# Altium schematic sheet sheet02.SchDoc
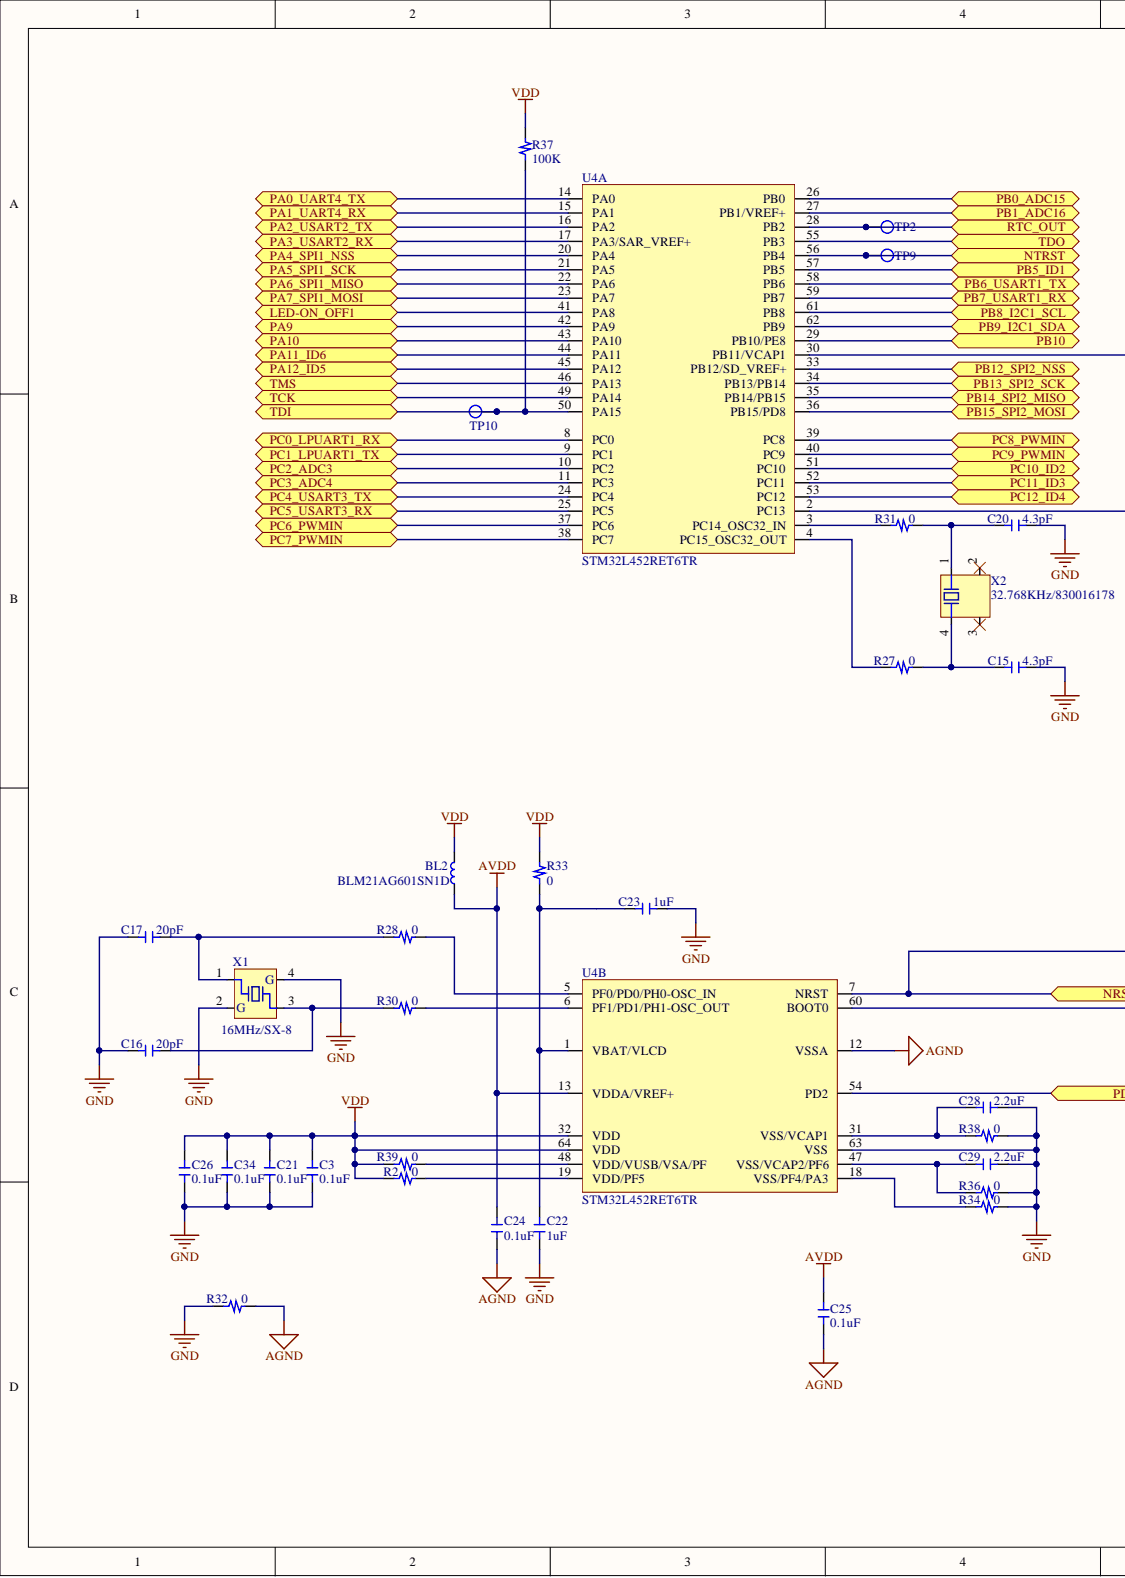
[diagram p0: sheet02.SchDoc, left]
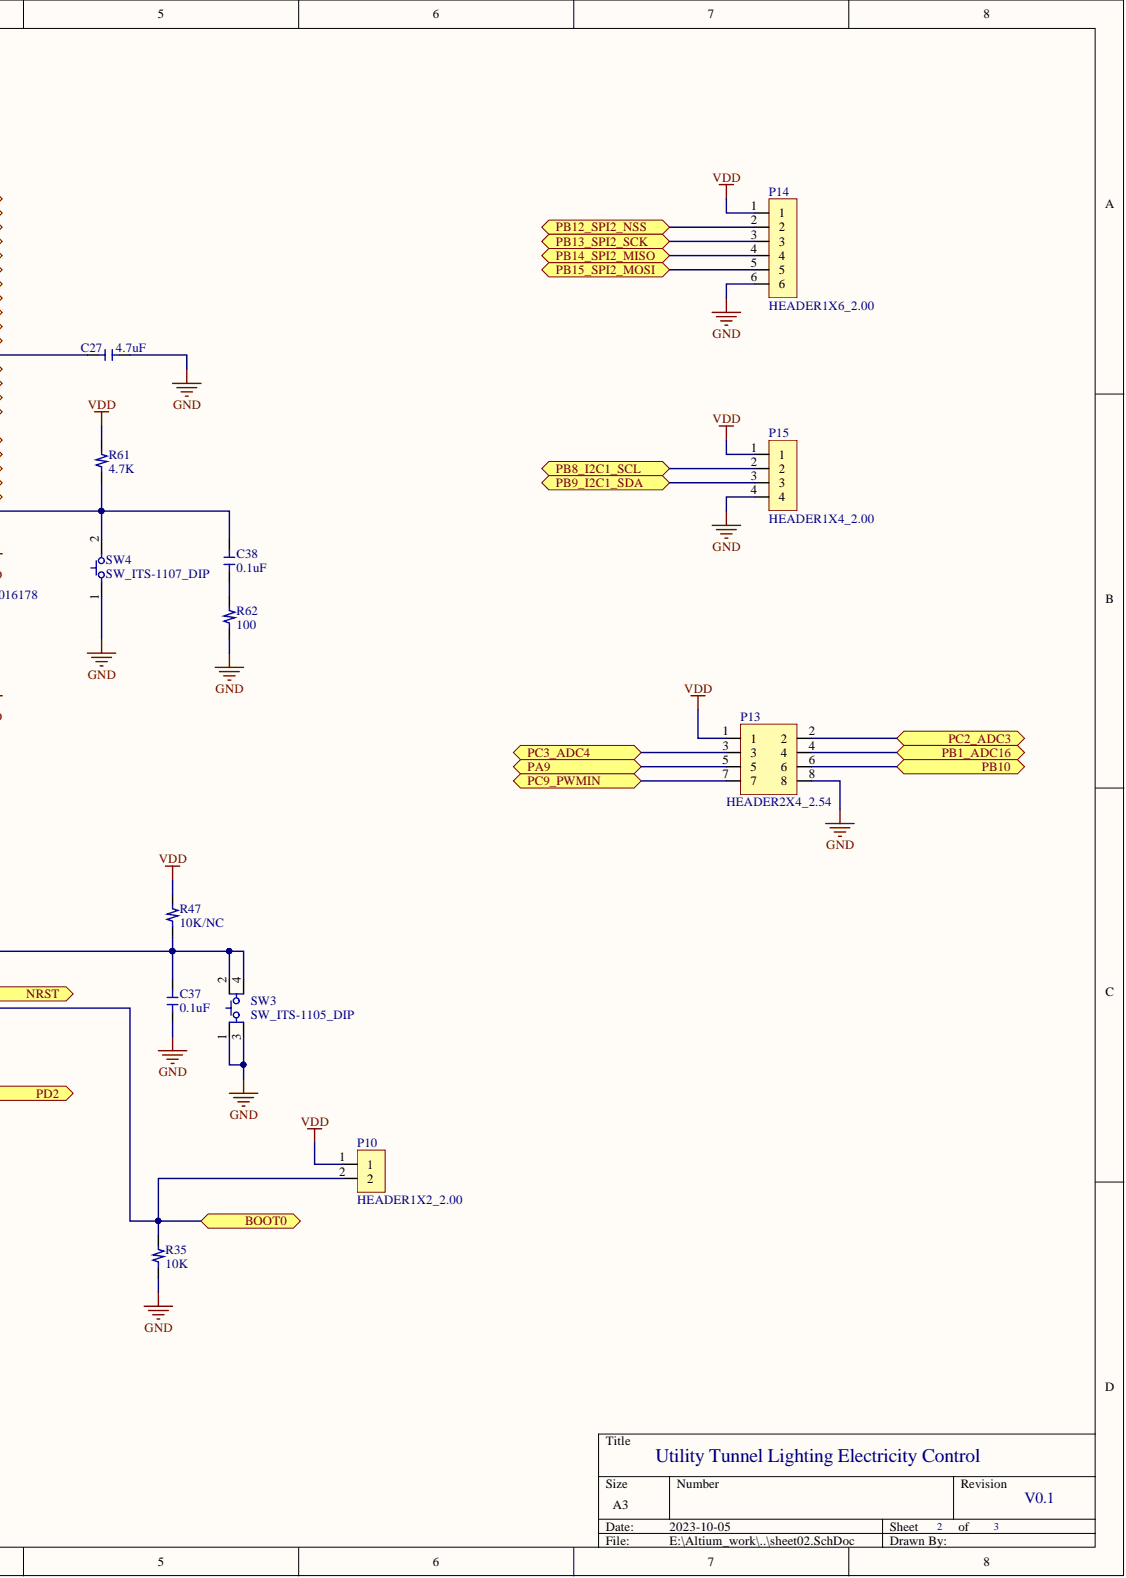
[diagram p1: sheet02.SchDoc, right]

## Parts
BL2  BLM21AG601SN1D  [BLM21P]
C3  0.1uF  [CC1608]
C15  4.3pF  [CC1608]
C16  20pF  [CC1608]
C17  20pF  [CC1608]
C20  4.3pF  [CC1608]
C21  0.1uF  [CC1608]
C22  1uF  [CC1608]
C23  1uF  [CC1608]
C24  0.1uF  [CC1608]
C25  0.1uF  [CC1608]
C26  0.1uF  [CC1608]
C27  4.7uF  [CC1608]
C28  2.2uF  [CC1608]
C29  2.2uF  [CC1608]
C34  0.1uF  [CC1608]
C37  0.1uF  [CC1608]
C38  0.1uF  [CC1608]
P10  HEADER1X2_2.00  [HEADER1X2_2.00]
P13  HEADER2X4_2.54  [HEADER2X4_2.54]
P14  HEADER1X6_2.00  [HEADER1X6_2.00]
P15  HEADER1X4_2.00  [HEADER1X4_2.00]
R2  0  [RC2012]
R27  0  [RC1608]
R28  0  [RC1608]
R30  0  [RC1608]
R31  0  [RC1608]
R32  0  [RC2012]
R33  0  [RC2012]
R34  0  [RC1608]
R35  10K  [RC1608]
R36  0  [RC1608]
R37  100K  [RC1608]
R38  0  [RC1608]
R39  0  [RC2012]
R47  10K/NC  [RC1608]
R61  4.7K  [RC1608]
R62  100  [RC1608]
SW3  SW_ITS-1105_DIP  [SW_ITS-1105_DIP]
SW4  SW_ITS-1107_DIP  [SW_ITS-1107_DIP]
TP2  TP  [TP_0.6]
TP9  TP  [TP_0.9]
TP10  TP  [TP_0.9]
U4  STM32L452RET6TR  [LQFP64_10X10_0.5_H1.6]  (parts A,B)
X1  16MHz/SX-8  [XTAL_SX-8]
X2  32.768KHz/830016178  [XTAL_830016178]

## Ports
BOOT0, LED-ON_OFF1, NRST, NTRST, PA0_UART4_TX, PA1_UART4_RX, PA2_USART2_TX, PA3_USART2_RX, PA4_SPI1_NSS, PA5_SPI1_SCK, PA6_SPI1_MISO, PA7_SPI1_MOSI, PA9, PA10, PA11_ID6, PA12_ID5, PB0_ADC15, PB1_ADC16, PB5_ID1, PB6_USART1_TX, PB7_USART1_RX, PB8_I2C1_SCL, PB9_I2C1_SDA, PB10, PB12_SPI2_NSS, PB13_SPI2_SCK, PB14_SPI2_MISO, PB15_SPI2_MOSI, PC0_LPUART1_RX, PC1_LPUART1_TX, PC2_ADC3, PC3_ADC4, PC4_USART3_TX, PC5_USART3_RX, PC6_PWMIN, PC7_PWMIN, PC8_PWMIN, PC9_PWMIN, PC10_ID2, PC11_ID3, PC12_ID4, PD2, RTC_OUT, TCK, TDI, TDO, TMS

## Nets
AGND: C24.1 C25.1 R32.2 U4.12(VSSA)
AVDD: BL2.1 C24.2 C25.2 U4.13(VDDA/VREF+)
GND: C3.1 C15.2 C16.1 C17.1 C20.2 C21.1 C22.1 C23.2 C26.1 C27.2 C28.2 C29.2 C34.1 C37.1 P13.8 P14.6 P15.4 R32.1 R34.2 R35.1 R36.2 R38.2 R62.1 SW3.1 SW3.3 SW4.1 U4.63(VSS) X1.2(G) X1.4(G)
VDD: BL2.2 C3.2 C21.2 C26.2 C34.2 P10.1 P13.1 P14.1 P15.1 R2.1 R33.2 R37.2 R39.1 R47.2 R61.2 U4.32(VDD) U4.64(VDD)
BOOT0: P10.2 R35.2 U4.60(BOOT0) ; port BOOT0
LED-ON_OFF1: U4.41(PA8) ; port LED-ON_OFF1
NRST: C37.2 R47.1 SW3.2 SW3.4 U4.7(NRST) ; port NRST
NTRST: TP9.1 U4.56(PB4) ; port NTRST
PA0_UART4_TX: U4.14(PA0) ; port PA0_UART4_TX
PA1_UART4_RX: U4.15(PA1) ; port PA1_UART4_RX
PA2_USART2_TX: U4.16(PA2) ; port PA2_USART2_TX
PA3_USART2_RX: U4.17(PA3/SAR_VREF+) ; port PA3_USART2_RX
PA4_SPI1_NSS: U4.20(PA4) ; port PA4_SPI1_NSS
PA5_SPI1_SCK: U4.21(PA5) ; port PA5_SPI1_SCK
PA6_SPI1_MISO: U4.22(PA6) ; port PA6_SPI1_MISO
PA7_SPI1_MOSI: U4.23(PA7) ; port PA7_SPI1_MOSI
PA9: P13.5 U4.42(PA9) ; port PA9
PA10: U4.43(PA10) ; port PA10
PA11_ID6: U4.44(PA11) ; port PA11_ID6
PA12_ID5: U4.45(PA12) ; port PA12_ID5
PB0_ADC15: U4.26(PB0) ; port PB0_ADC15
PB1_ADC16: P13.4 U4.27(PB1/VREF+) ; port PB1_ADC16
PB5_ID1: U4.57(PB5) ; port PB5_ID1
PB6_USART1_TX: U4.58(PB6) ; port PB6_USART1_TX
PB7_USART1_RX: U4.59(PB7) ; port PB7_USART1_RX
PB8_I2C1_SCL: P15.2 U4.61(PB8) ; port PB8_I2C1_SCL
PB9_I2C1_SDA: P15.3 U4.62(PB9) ; port PB9_I2C1_SDA
PB10: P13.6 U4.29(PB10/PE8) ; port PB10
PB12_SPI2_NSS: P14.2 U4.33(PB12/SD_VREF+) ; port PB12_SPI2_NSS
PB13_SPI2_SCK: P14.3 U4.34(PB13/PB14) ; port PB13_SPI2_SCK
PB14_SPI2_MISO: P14.4 U4.35(PB14/PB15) ; port PB14_SPI2_MISO
PB15_SPI2_MOSI: P14.5 U4.36(PB15/PD8) ; port PB15_SPI2_MOSI
PC0_LPUART1_RX: U4.8(PC0) ; port PC0_LPUART1_RX
PC1_LPUART1_TX: U4.9(PC1) ; port PC1_LPUART1_TX
PC2_ADC3: P13.2 U4.10(PC2) ; port PC2_ADC3
PC3_ADC4: P13.3 U4.11(PC3) ; port PC3_ADC4
PC4_USART3_TX: U4.24(PC4) ; port PC4_USART3_TX
PC5_USART3_RX: U4.25(PC5) ; port PC5_USART3_RX
PC6_PWMIN: U4.37(PC6) ; port PC6_PWMIN
PC7_PWMIN: U4.38(PC7) ; port PC7_PWMIN
PC8_PWMIN: U4.39(PC8) ; port PC8_PWMIN
PC9_PWMIN: P13.7 U4.40(PC9) ; port PC9_PWMIN
PC10_ID2: U4.51(PC10) ; port PC10_ID2
PC11_ID3: U4.52(PC11) ; port PC11_ID3
PC12_ID4: U4.53(PC12) ; port PC12_ID4
PD2: U4.54(PD2) ; port PD2
RTC_OUT: TP2.1 U4.28(PB2) ; port RTC_OUT
TCK: U4.49(PA14) ; port TCK
TDI: R37.1 TP10.1 U4.50(PA15) ; port TDI
TDO: U4.55(PB3) ; port TDO
TMS: U4.46(PA13) ; port TMS
NetC15_1: C15.1 R27.2 X2.4
NetC16_2: C16.2 R30.1 X1.3
NetC17_2: C17.2 R28.1 X1.1
NetC20_1: C20.1 R31.2 X2.1
NetC22_2: C22.2 C23.1 R33.1 U4.1(VBAT/VLCD)
NetC27_1: C27.1 U4.30(PB11/VCAP1)
NetC28_1: C28.1 R38.1 U4.31(VSS/VCAP1)
NetC29_1: C29.1 R36.1 U4.47(VSS/VCAP2/PF6)
NetC38_1: C38.1 R62.2
NetC38_2: C38.2 R61.1 SW4.2 U4.2(PC13)
NetR2_2: R2.2 U4.19(VDD/PF5)
NetR27_1: R27.1 U4.4(PC15_OSC32_OUT)
NetR28_2: R28.2 U4.5(PF0/PD0/PH0-OSC_IN)
NetR30_2: R30.2 U4.6(PF1/PD1/PH1-OSC_OUT)
NetR31_1: R31.1 U4.3(PC14_OSC32_IN)
NetR34_1: R34.1 U4.18(VSS/PF4/PA3)
NetR39_2: R39.2 U4.48(VDD/VUSB/VSA/PF)

Not connected: X2 2 3

## Library data of the parts
BL2 = BEAD: Component Kind: Standard; Library Name: knb_lib.IntLib
C3 C15-C17 C20-C29 C34 C37 C38 = CAP: Ceramic CAP; Part: Passive; Component Kind: Standard; Contact Point: Contact Point; Library Name: knb_lib.IntLib
R2 R27 R28 R30-R39 R47 R61 R62 = RES: Part: Passive; Component Kind: Standard; Description: RES; Library Name: knb_lib.IntLib
U4 = STM32L452RET: Component Kind: Standard; Library Name: knb_lib.IntLib
X1 = XTAL_SX-8: Component Kind: Standard; Library Name: knb_lib.IntLib
X2 = XTAL_830016178: Component Kind: Standard; Library Name: SW_XTAL_PWR_ETC.SchLib
P10 = HEADER1X2_2.00: Component Kind: Standard; Library Name: CONNECTOR.SchLib
P13 = HEADER2X4_2.54: Component Kind: Standard; Library Name: CONNECTOR.SchLib
P14 = HEADER1X6_2.00: Component Kind: Standard; Library Name: CONNECTOR.SchLib
P15 = HEADER1X4_2.00: Component Kind: Standard; Library Name: CONNECTOR.SchLib
SW3 = SW_ITS-1105_DIP: Component Kind: Standard; Library Name: knb_lib.IntLib
SW4 = SW_ITS-1107_DIP: Component Kind: Standard; Library Name: SW_XTAL_PWR_ETC.SchLib
TP2 TP9 TP10 = TP: Component Kind: Standard; Library Name: CONNECTOR.SchLib
